# Altium schematic sheet Main.SchDoc(Main)
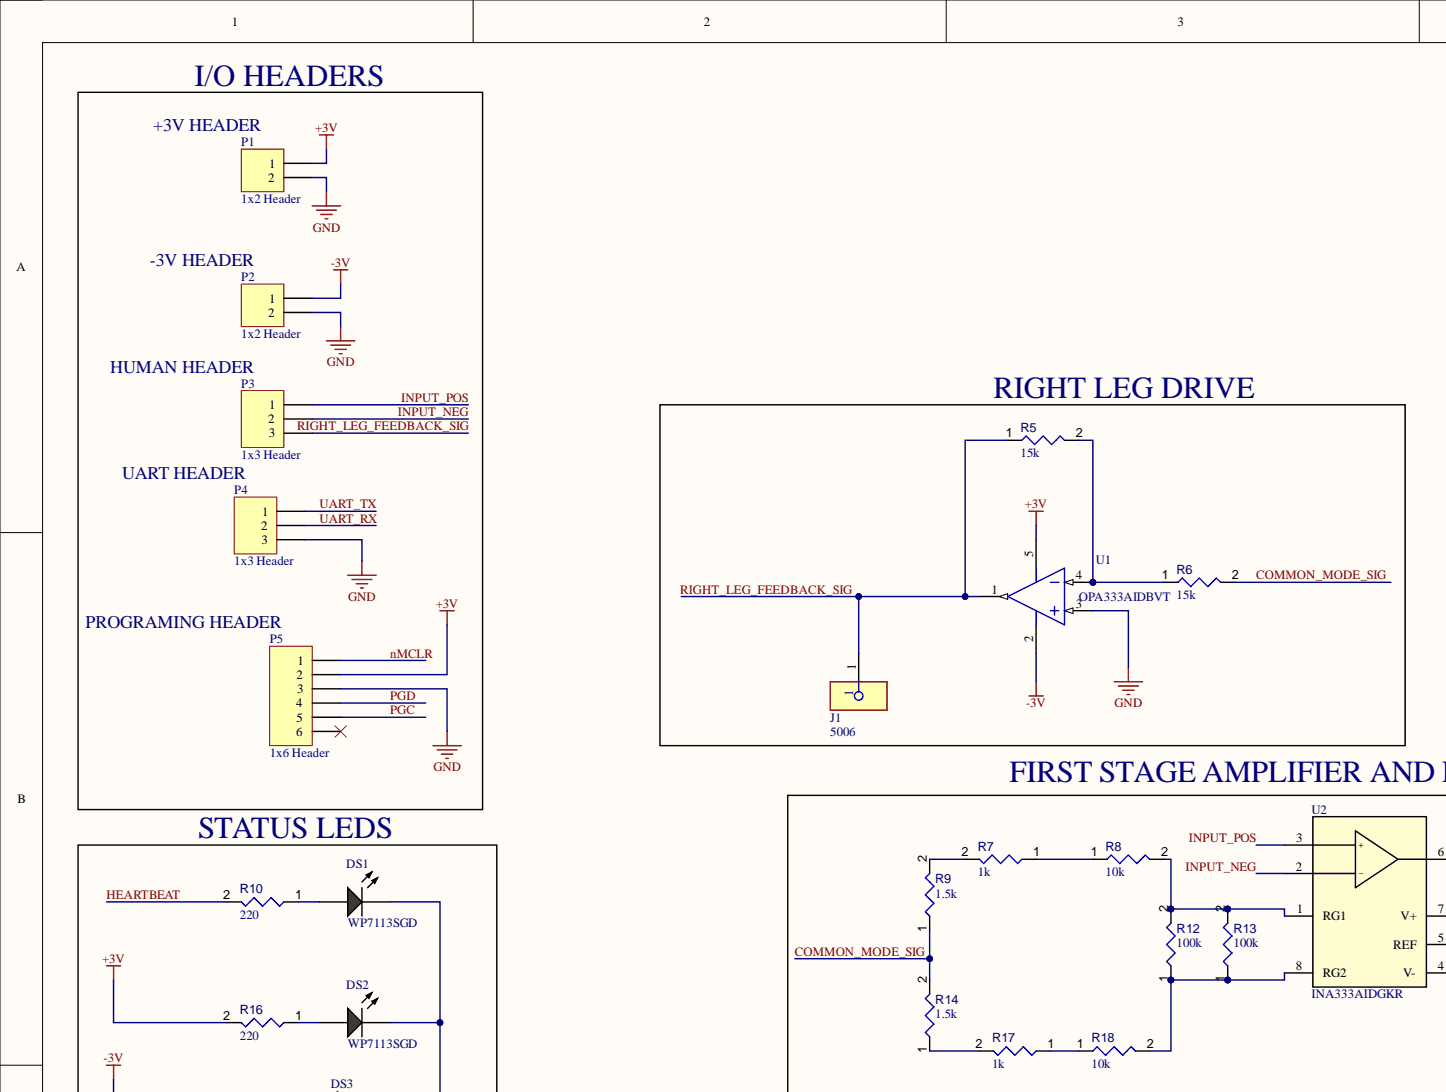
[diagram p0: Main.SchDoc, top left]
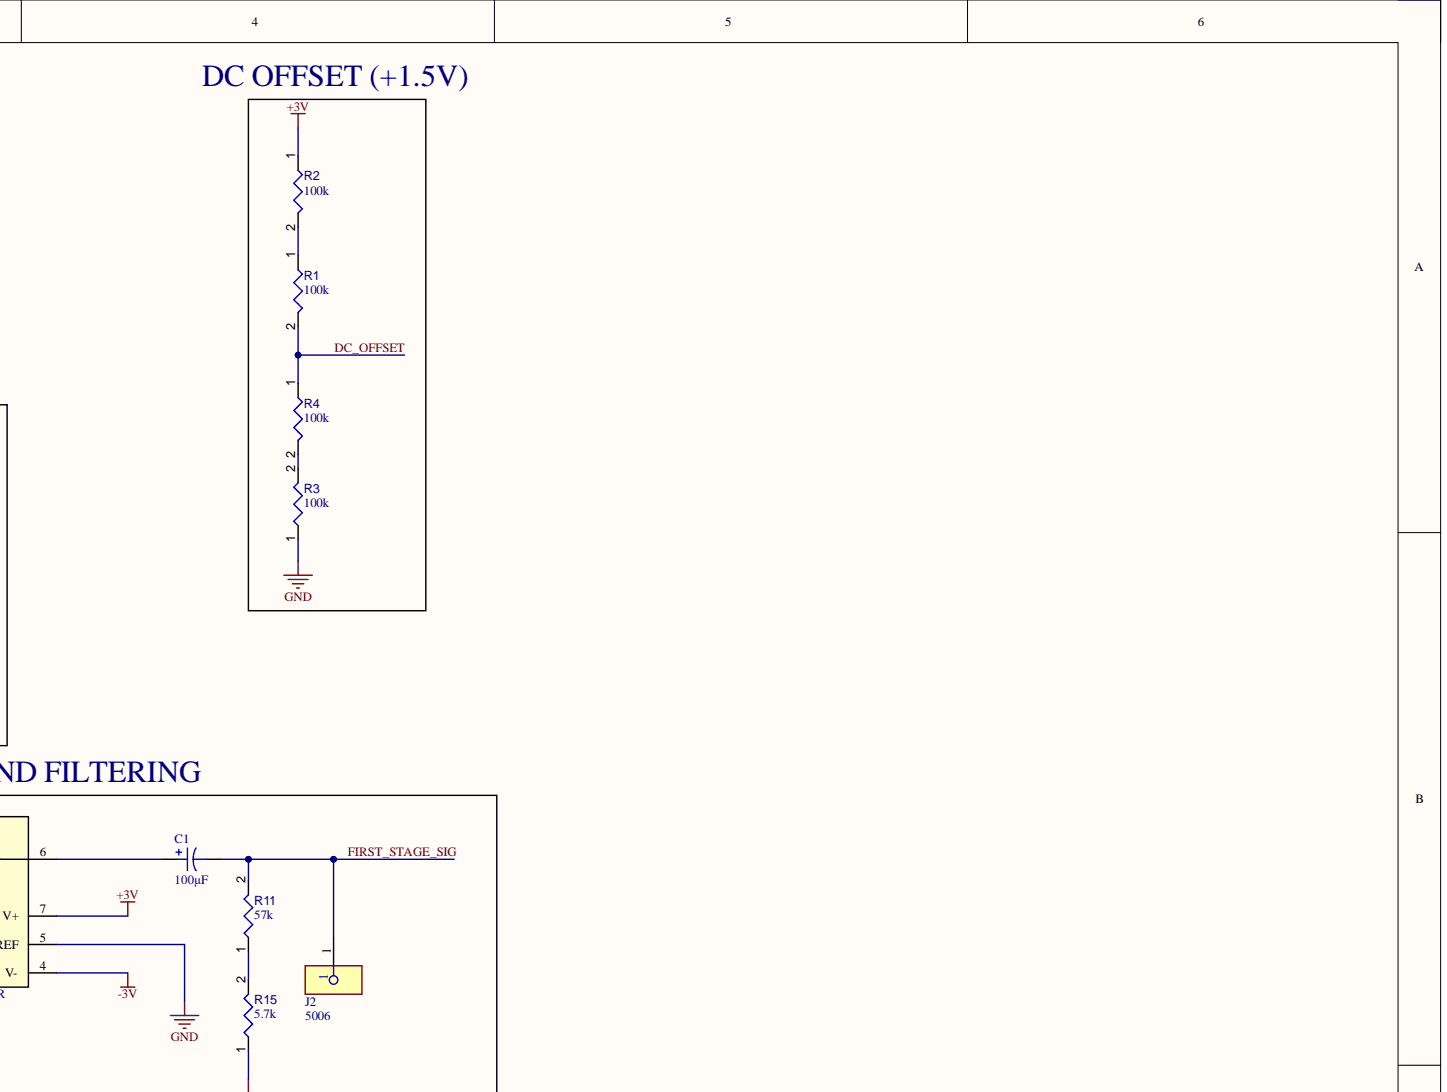
[diagram p1: Main.SchDoc, top right]
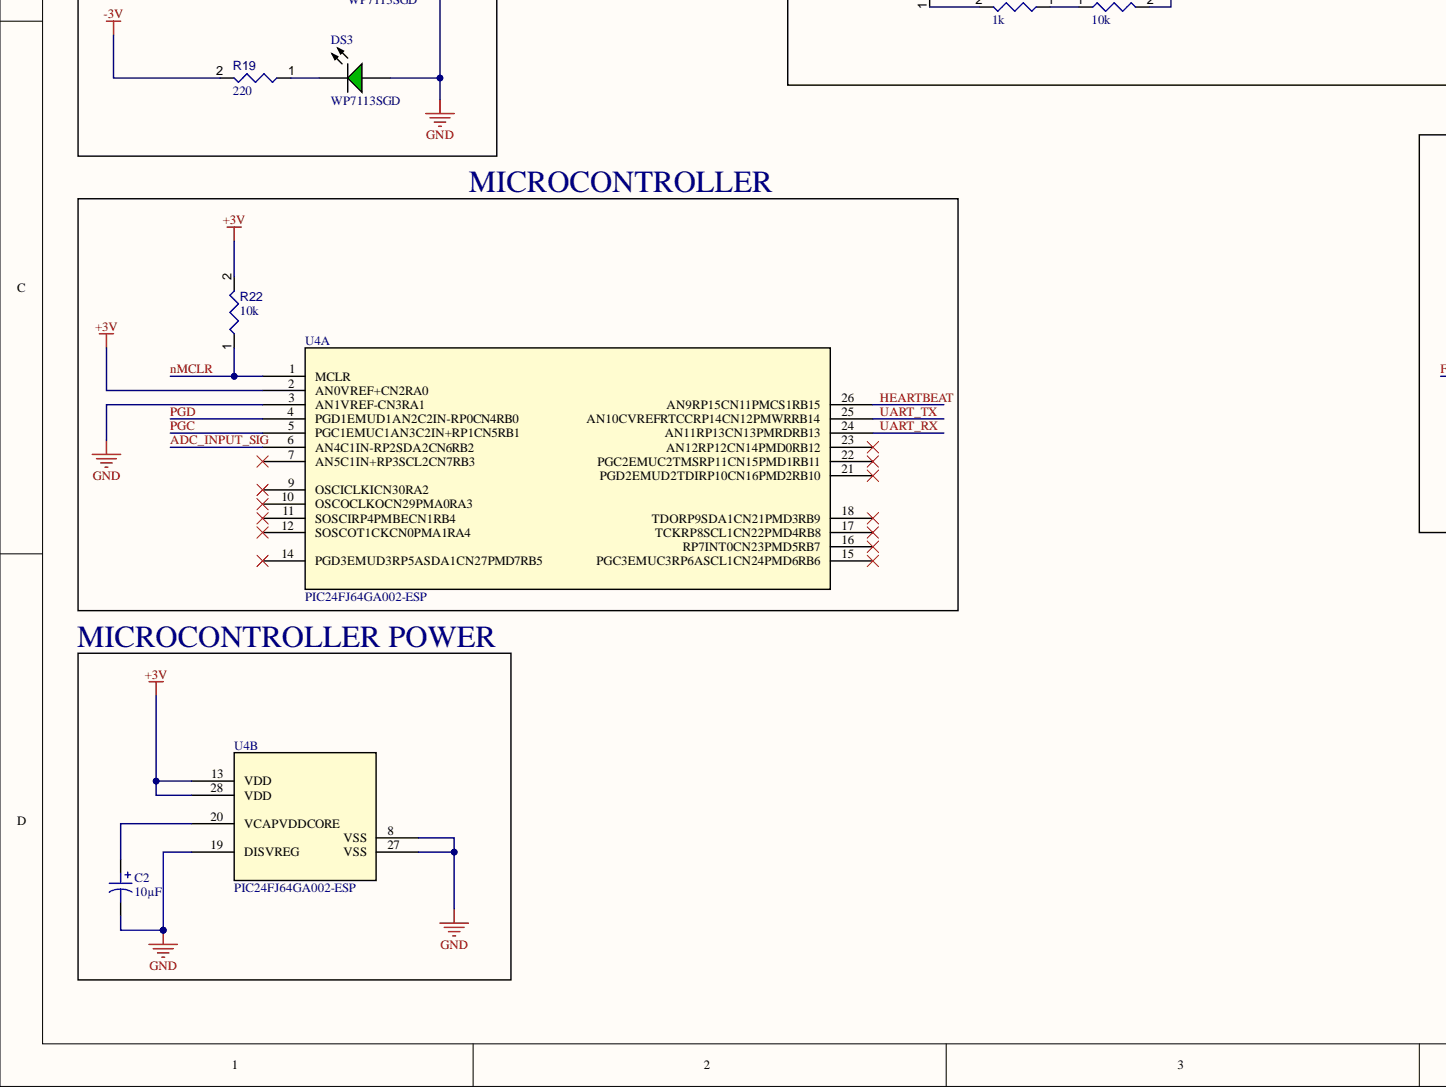
[diagram p2: Main.SchDoc, bottom left]
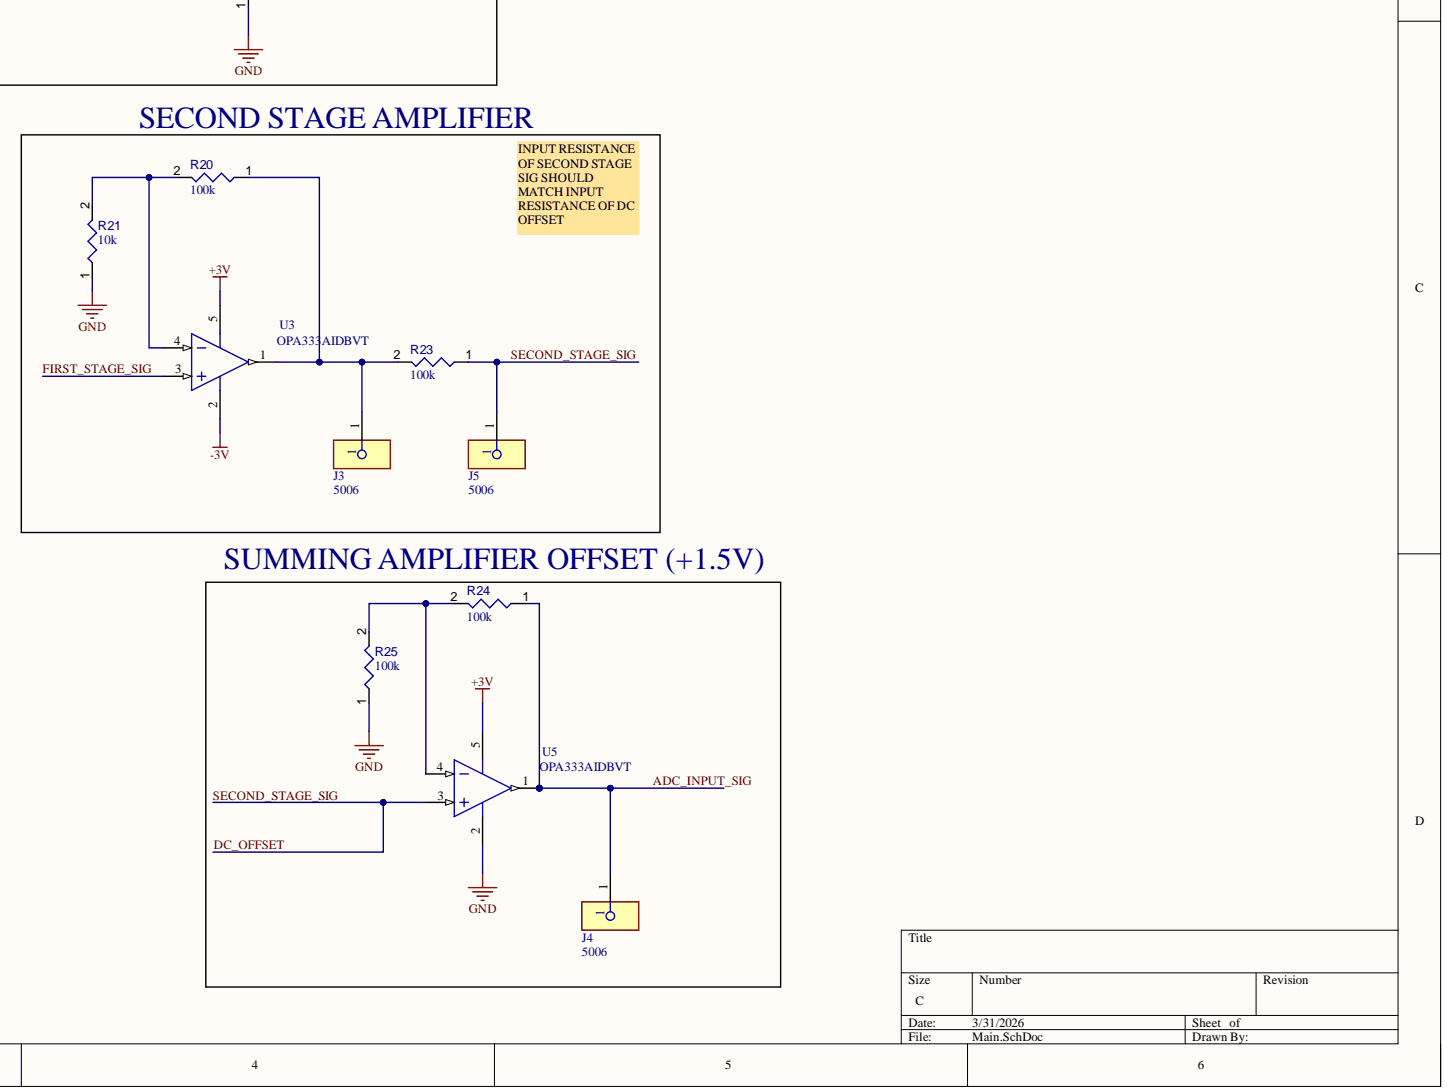
[diagram p3: Main.SchDoc, bottom right]

## Parts
C1  value 100µF  Capacitance: 100µF  (hidden comment: UKW1V103MRD)
C2  value 10µF  Capacitance: 10µF  (hidden comment: UKW1V103MRD)
DS1  WP7113SGD
DS2  WP7113SGD
DS3  WP7113SGD
J1  5006
J2  5006
J3  5006
J4  5006
J5  5006
P1  1x2 Header
P2  1x2 Header
P3  1x3 Header
P4  1x3 Header
P5  1x6 Header
R1  value 100k  (hidden comment: MFR-25FBF52-10K)
R2  value 100k  (hidden comment: MFR-25FBF52-10K)
R3  value 100k  (hidden comment: MFR-25FBF52-10K)
R4  value 100k  (hidden comment: MFR-25FBF52-10K)
R5  value 15k  (hidden comment: MFR-25FBF52-10K)
R6  value 15k  (hidden comment: MFR-25FBF52-10K)
R7  value 1k  (hidden comment: MFR-25FBF52-10K)
R8  value 10k  (hidden comment: MFR-25FBF52-10K)
R9  value 1.5k  (hidden comment: MFR-25FBF52-10K)
R10  value 220  (hidden comment: MFR-25FBF52-10K)
R11  value 57k  (hidden comment: MFR-25FBF52-10K)
R12  value 100k  (hidden comment: MFR-25FBF52-10K)
R13  value 100k  (hidden comment: MFR-25FBF52-10K)
R14  value 1.5k  (hidden comment: MFR-25FBF52-10K)
R15  value 5.7k  (hidden comment: MFR-25FBF52-10K)
R16  value 220  (hidden comment: MFR-25FBF52-10K)
R17  value 1k  (hidden comment: MFR-25FBF52-10K)
R18  value 10k  (hidden comment: MFR-25FBF52-10K)
R19  value 220  (hidden comment: MFR-25FBF52-10K)
R20  value 100k  (hidden comment: MFR-25FBF52-10K)
R21  value 10k  (hidden comment: MFR-25FBF52-10K)
R22  value 10k  (hidden comment: MFR-25FBF52-10K)
R23  value 100k  (hidden comment: MFR-25FBF52-10K)
R24  value 100k  (hidden comment: MFR-25FBF52-10K)
R25  value 100k  (hidden comment: MFR-25FBF52-10K)
U1  OPA333AIDBVT
U2  INA333AIDGKR
U3  OPA333AIDBVT
U4  PIC24FJ64GA002-ESP  (value not drawn)  (parts A,B)
U5  OPA333AIDBVT

## Nets
+3V: P1.1 P5.2 R2.1 R16.2 R22.2 U1.5 U2.7(V+) U3.5 U4.2(AN0VREF+CN2RA0) U4.13(VDD) U4.28(VDD) U5.5
-3V: P2.1 R19.2 U1.2 U2.4(V-) U3.2
GND: C2.2 DS1.2 DS2.2 DS3.1 P1.2 P2.2 P4.3 P5.3 R3.1 R15.1 R21.1 R25.1 U1.3 U2.5(REF) U4.3(AN1VREF-CN3RA1) U4.8(VSS) U4.19(DISVREG) U4.27(VSS) U5.2
ADC_INPUT_SIG: J4.1 R24.1 U4.6(AN4C1IN-RP2SDA2CN6RB2) U5.1
COMMON_MODE_SIG: R6.2 R9.1 R14.2
DC_OFFSET: J5.1 R1.2 R4.1 R23.1 U5.3
FIRST_STAGE_SIG: C1.2 J2.1 R11.2 U3.3
HEARTBEAT: R10.2 U4.26(AN9RP15CN11PMCS1RB15)
INPUT_NEG: P3.2 U2.2
INPUT_POS: P3.1 U2.3
nMCLR: P5.1 R22.1 U4.1(MCLR)
PGC: P5.5 U4.5(PGC1EMUC1AN3C2IN+RP1CN5RB1)
PGD: P5.4 U4.4(PGD1EMUD1AN2C2IN-RP0CN4RB0)
RIGHT_LEG_FEEDBACK_SIG: J1.1 P3.3 R5.1 U1.1
UART_RX: P4.2 U4.24(AN11RP13CN13PMRDRB13)
UART_TX: P4.1 U4.25(AN10CVREFRTCCRP14CN12PMWRRB14)
NetC1_1: C1.1 U2.6
NetC2_1: C2.1 U4.20(VCAPVDDCORE)
NetDS1_1: DS1.1 R10.1
NetDS2_1: DS2.1 R16.1
NetDS3_2: DS3.2 R19.1
NetJ3_1: J3.1 R20.1 R23.2 U3.1
NetR1_1: R1.1 R2.2
NetR3_2: R3.2 R4.2
NetR5_2: R5.2 R6.1 U1.4
NetR7_1: R7.1 R8.1
NetR7_2: R7.2 R9.2
NetR8_2: R8.2 R12.2 R13.2 U2.1(RG1)
NetR11_1: R11.1 R15.2
NetR12_1: R12.1 R13.1 R18.2 U2.8(RG2)
NetR14_1: R14.1 R17.2
NetR17_1: R17.1 R18.1
NetR20_2: R20.2 R21.2 U3.4
NetR24_2: R24.2 R25.2 U5.4

Not connected: P5 6; U4 7(AN5C1IN+RP3SCL2CN7RB3) 9(OSCICLKICN30RA2) 10(OSCOCLKOCN29PMA0RA3) 11(SOSCIRP4PMBECN1RB4) 12(SOSCOT1CKCN0PMA1RA4) 14(PGD3EMUD3RP5ASDA1CN27PMD7RB5) 15(PGC3EMUC3RP6ASCL1CN24PMD6RB6) 16(RP7INT0CN23PMD5RB7) 17(TCKRP8SCL1CN22PMD4RB8) 18(TDORP9SDA1CN21PMD3RB9) 21(PGD2EMUD2TDIRP10CN16PMD2RB10) 22(PGC2EMUC2TMSRP11CN15PMD1RB11) 23(AN12RP12CN14PMD0RB12)

## Library data of the parts
C1 C2 = CMP-178-000373-1: CAP ALUM 10000UF 20% 35V RADIAL; manufacturer: Nichicon; Lifetime @ Temp.: 2000 Hrs @ 85°C; Polarization: Polar; Ripple Current @ High Frequency: 4.255A @ 10kHz; Ripple Current @ Low Frequency: 3.7A @ 120Hz; Applications: Audio; Component Kind: Standard; ComponentLink1Description: Datasheet; ComponentLink1URL: http://nichicon-us.com/english/products/pdfs/e-ukw.pdf; ComponentLink2Description: DigiKey Link; ComponentLink2URL: http://digikey.com/product-detail/en/nichicon/UKW1V103MRD/493-10697-ND/1964251; Device Package: Radial, Can; Height - Seated (Max): 1.969" (50.00mm); lastupdated: 9/2/2020 1:30:07 AM; Lead Spacing: 0.394" (10.00mm); Lifecycle Status: Active; Minimum Order: 1; Mounting Type: Through Hole; Operating Temperature: -40°C ~ 85°C; Package / Case: Radial, Can; packaging: Bulk; Part Number: UKW1V103MRD; Part Status: Active; partid: 9596; price: 4.40; series: UKW; Size / Dimension: 0.866" Dia (22.00mm); Tolerance: ±20%; Voltage - Rated: 35V; Library Name: Gopher Motorsports
DS1-DS3 = CMP-007-00010-1: LED Green, 62.5 mW, 25 mA, -40 to 85 degC, 2-Pin THD, RoHS, Bulk; Manufacturer: Kingbright; Forward Voltage: 2.2V; Lens Style: Dome; Luminous Intensity: 40mcd; Power: 62.5mW; Viewing Angle: 30degrees; Case/Package: Radial; Colour: Green; Component Kind: Standard; Datasheet URL: http://www.kingbrightusa.com/images/catalog/SPEC/WP7113SGD.pdf; Datasheet Version: Rev. 6B, 01/2015; Illumination Colour: Green; Mounting Technology: TH; Nominal Vf: 2.2V; Package Description: 2-Pin Through Hole Device, Dia 5.9 mm, Body Length 8.6 mm; Package Quantity: 1000; Package Reference: WP7113SGD; Packaging: Bulk; RoHS Compliant: True; Library Name: Gopher Motorsports
J1-J5 = CMP-00042-1: PCB Test Point Black 1/8" Diameter x 0.22"L Silver Plated Nylon Insulated; Component Kind: Standard; Library Name: Gopher Motorsports
P1 P2 = CMP-003-000017-3: Male Header, Dupont, 1x2; Component Kind: Standard; Design Item ID: 1x2 Header; Source: 1x2 Header.SchLib; Library Name: Gopher Motorsports
P3 P4 = CMP-002-000253-2: Male Header, Dupont, 1x3; Component Kind: Standard; Design Item ID: 1x3 Header; Source: 1x3 Header.SchLib; Library Name: Gopher Motorsports
P5 = CMP-010-000000-2: Male Header, Dupont, 1x6; Component Kind: Standard; Design Item ID: 1x6 Header; Source: 1x6 Header.SchLib; Library Name: Gopher Motorsports
R1-R25 = CMP-009-00047-3: Axial Resistor, 10 KOhm, +/- 1%, 0.25 W, -55 to 155 degC, 2-Pin THD, RoHS, Bulk; Manufacturer: Yageo; Power: 250mW; Voltage Rating: 250V; Case/Package: Axial; Component Kind: Standard; Datasheet URL: http://www.yageo.com/documents/recent/Yageo%20LR_MFR_2013.pdf; Datasheet Version: Apr-13; Manufacturer URL: http://www.yageo.com/; Max Operating Temperature: 155°C; Min Operating Temperature: -55°C; Mounting Technology: TH; Package Description: 2-Pin Axial Lead, Dia 2.4 mm, Body Length 6.3 mm; Package Quantity: 200; Package Reference: MFR-25; Packaging: Bulk; RoHS Compliant: True; Tolerance: 1%; Library Name: Gopher Motorsports
U1 U3 U5 = CMP-014-00019-1: MicroPower, Zero Drift CMOS Operational Amplifier, 1.8 to 5.5 V, 17 uA, -40 to 125 degC, 5-Pin SOT23 (DBV5), Green (RoHS & no Sb/Br), Tape and Reel; Manufacturer: Texas Instruments (TI); Channels: 1; Common Mode Rejection Ratio: 106dB; Max Dual Supply Voltage: 2.75V; Max I/O Voltage: 10uV; Min Dual Supply Voltage: 900mV; Min Supply Voltage: 9dV; Nominal Gain Bandwith Product: 350KHz; Nominal Supply Current: 25uA; Nominal Voltage Gain: 130dB; Output Current: 5mA; Slew Rate: 0.16V/us; Case/Package: SOT-23-5; Component Kind: Standard; Datasheet URL: http://www.ti.com/general/docs/lit/getliterature.tsp?genericPartNumber=OPA333&fileType=pdf; Datasheet Version: SBOS351E, 12/2015; Manufacturer URL: http://www.ti.com/; Max Operating Temperature: 125°C; Max Supply Voltage: 2.75V; Min Operating Temperature: -40°C; Mounting Technology: SM; Package Description: 5-Pin Small Outline Transistor, Body 2.9 x 1.6 mm, Pitch 0.95 mm; Package Quantity: 250; Package Reference: DBV0005A; Package URL: http://www.ti.com/lit/ml/mpds018l/mpds018l.pdf; Packaging: TapeandReel; Pins: 5; RoHS Compliant: True; Unity Gain Bandwidth Product: 350KHz; Library Name: Gopher Motorsports
U2 = CMP-00039-3: Low-Power, Zero-Drift, Precision Instrumentation Amplifier 8-VSSOP -40 to 125; Manufacturer_Name: Texas Instruments; Component Kind: Standard; Design Item ID: INA333AIDGKR; Manufacturer_Part_Number: INA333AIDGKR; RefDes: RefDes; Source: INA333AIDGKR.SchLib; TYPE: TYPE; Copyright: Copyright (C) 2025 Ultra Librarian. All rights reserved.; Library Name: Gopher Motorsports
U4 = CMP-488-000000-1: No Description Available; Manufacturer_Part_Number: PIC24FJ64GA002-E/SP; Mfr_Name: Microchip; Component Kind: Standard; Design Item ID: PIC24FJ64GA002-ESP; RefDes: RefDes; Source: PIC24FJ64GA002-ESP.SchLib; Type: DEV; Copyright: Copyright (C) 2024 Ultra Librarian. All rights reserved.; Library Name: Gopher Motorsports
